# Altium schematic sheet schema.SchDoc(schema)
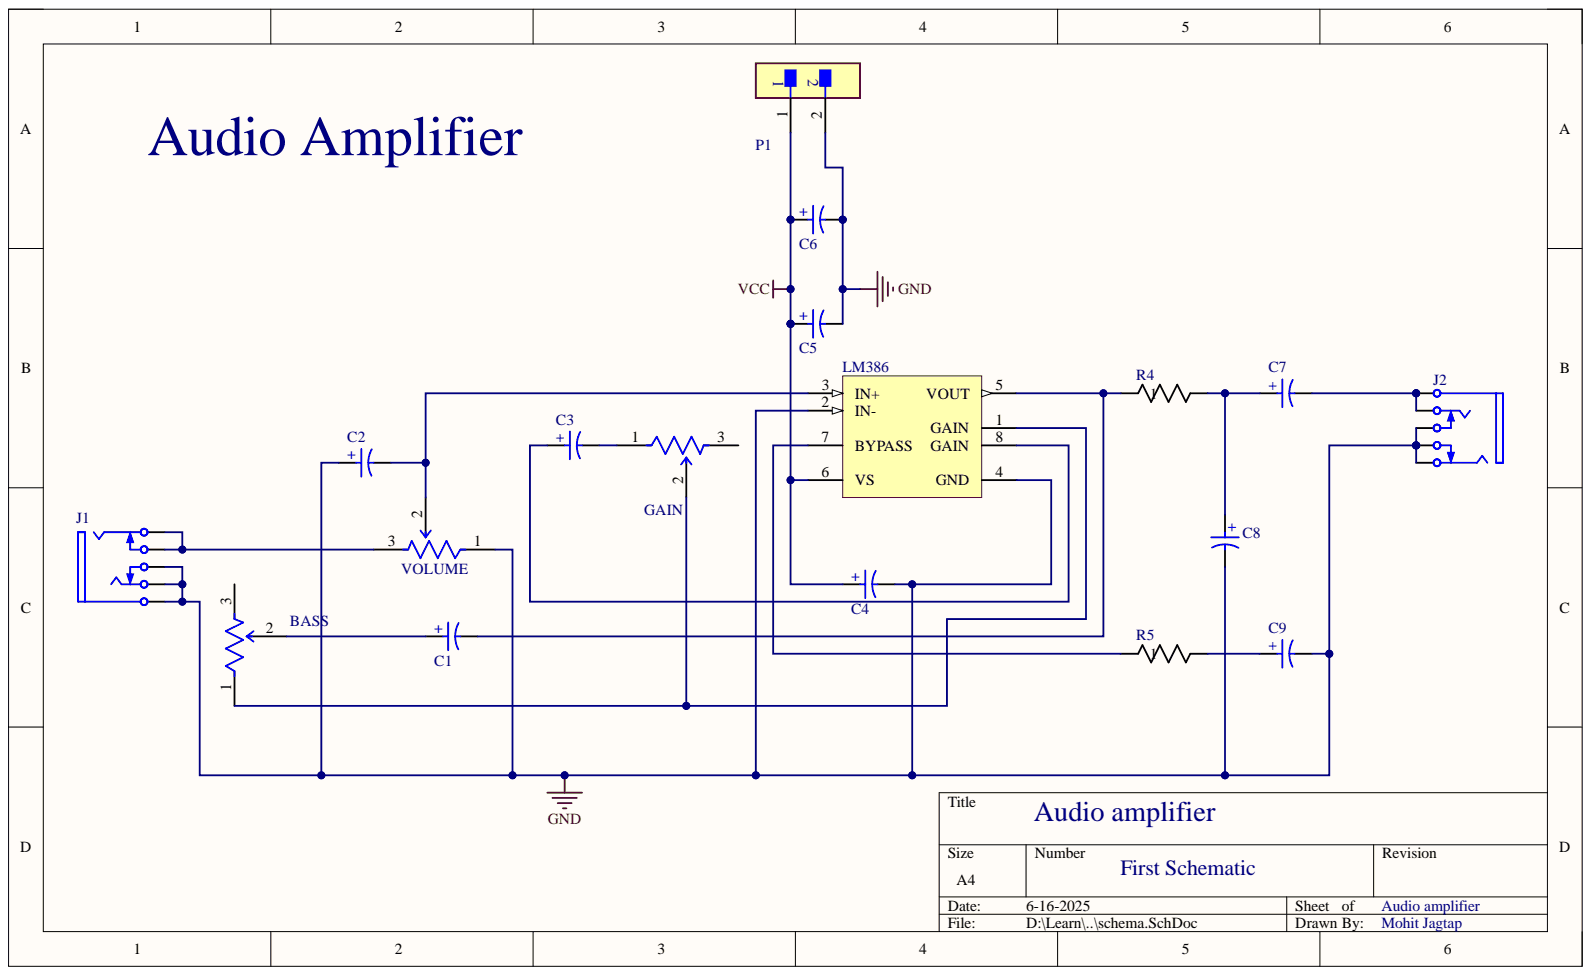
[diagram p0: schema.SchDoc]

## Parts
BASS  3352T-1-103LF  [FP-3352T-MFG]  (value not drawn)
C1  860020678025  [WCAP-ATG5_D13H25]  (value not drawn)
C2  860020678025  [WCAP-ATG5_D13H25]  (value not drawn)
C3  860020678025  [WCAP-ATG5_D13H25]  (value not drawn)
C4  860020678025  [WCAP-ATG5_D13H25]  (value not drawn)
C5  860020678025  [WCAP-ATG5_D13H25]  (value not drawn)
C6  860020678025  [WCAP-ATG5_D13H25]  (value not drawn)
C7  860020678025  [WCAP-ATG5_D13H25]  (value not drawn)
C8  860020678025  [WCAP-ATG5_D13H25]  (value not drawn)
C9  860020678025  [WCAP-ATG5_D13H25]  (value not drawn)
GAIN  3352T-1-103LF  [FP-3352T-MFG]  (value not drawn)
J1  35RASMT4BHNTRX  [SWIT-35RASMT4BHNTRX_V]  (value not drawn)
J2  35RASMT4BHNTRX  [SWIT-35RASMT4BHNTRX_V]  (value not drawn)
LM386  LM386M-1  [D0008A_M]  (value not drawn)
P1  M20-9990245  [FP-M20-9990245-MFG]  (value not drawn)
R4  AC01000006809JA100  [RES_AC01_VIS]  (value not drawn)
R5  AC01000006809JA100  [RES_AC01_VIS]  (value not drawn)
VOLUME  3352T-1-103LF  [FP-3352T-MFG]  (value not drawn)

## Nets
GND: C2.1 C4.2 C5.2 C6.2 C8.2 C9.2 J1.1 J1.3 J1.5 J2.2 J2.4 J2.5 LM386.2(IN-) LM386.4(GND) P1.2 VOLUME.1
VCC: C4.1 C5.1 C6.1 LM386.6(VS) P1.1
NetBASS_1: BASS.1 GAIN.2 LM386.1(GAIN)
NetBASS_2: BASS.2 C1.1
NetC1_2: C1.2 LM386.5(VOUT) R4.1
NetC2_2: C2.2 LM386.3(IN+) VOLUME.2
NetC3_1: C3.1 LM386.8(GAIN)
NetC3_2: C3.2 GAIN.1
NetC7_1: C7.1 C8.1 R4.2
NetC7_2: C7.2 J2.1 J2.3
NetC9_1: C9.1 R5.2
NetJ1_2: J1.2 J1.4 VOLUME.3
NetLM386_7: LM386.7(BYPASS) R5.1

Not connected: BASS 3; GAIN 3

## Library data of the parts
BASS GAIN VOLUME = CMP-07234-000038-1: THUMBWHEEL POT 10K OHM 0.5W TOP; Max Power Dissipation: 500mW; Number of Turns: 1; Resistance: 10kR; Resistance Tolerance: 20%; Temperature Coefficient: 100ppm/°C; Voltage Rating: 250V; Color: Black; Component Kind: Standard; Contact Plating: Tin; Depth: 9.53mm; Diameter: 8.89mm; Height: 0.15inch; Lead Free: Lead Free; Length: 8.89mm; Library Name: Altium Content Vault; Max Operating Temperature: 125°C; Military Standard: Not; Min Operating Temperature: -55°C; Mount: Through Hole; Package Quantity: 100; Packaging: Bag; Power Rating: 500mW; Radiation Hardening: No; REACH SVHC: No SVHC; Resistor Type: Cermet; RoHS Compliant: Yes; Series: Trimpot® 3352 - Open Frame; Taper: Linear; Technology: CERMET; Termination: Pin; Tolerance: 20%; Weight: 0.01oz; Width: 8.89mm
C1-C9 = CMP-03913-54202230-1: 860020678025 Alum. Electrolytic Cap 820µF 50V 13mm Radial WCAP-ATG5; Lifetime 2000h +105°C; Leakage Current: 410µA; Life (Hours): 2000hours; Material: Aluminium; Pitch: 5mm; Polarity: Polar; Ripple Current: 850mA; Tolerance: 20%; Capacitance: 820µF; Case/Package: Radial; Component Kind: Standard; Diameter: 13mm; Height: 25mm; Height - Seated (Max): 26.4922mm; Lead Pitch: 5.0038mm; Length: 25mm; Library Name: Capacitor_Ele_TH.IntLib; Max Operating Temperature: 105°C; Min Operating Temperature: -40°C; Mount: Through Hole; NexarPartId: 54202230; Packaging: Tape & Box (TB); REACH SVHC: Unknown; RoHS: Compliant; Voltage Rating: 50V; Voltage Rating (DC): 50V
J1 J2 = CMP-1772-00001-1: Female 3.5 mm Phone Jack, 5 Position, Height 5 mm, RoHS, Tape and Reel; Current Rating: 50 mA; Voltage Rating (DC): 12 V; Component Kind: Standard; ComponentLink1Description: Manufacturer URL; ComponentLink1URL: http://www.switchcraft.com/; ComponentLink2Description: Datasheet; ComponentLink2URL: http://www.switchcraft.com/Drawings/35rasmt4bhntrx_cd.pdf; Contact Material: Silver; Contact Plating: Silver; DatasheetVersion: Rev. C, 07/2014; Library Name: Altium Content Vault; Mount: Through Hole; Package Quantity: 3000; PackageDescription: Female 3.5 mm Phone Jack, 5 Position, Height 5 mm; PackageReference: 35RASMT4BHNTRX; Packaging: Tape and Reel; RoHSCompliant: true
LM386 = CMP-0057-00421-4: Low Voltage Audio Power Amplifier, 8-pin Narrow SOIC; Manufacturer: Texas Instruments; CompType: Audio IC; PackageTopMarking: NSZXTT*LM386*M-1; PowerWise: N; Code_IPC: SOIC127P600-8; Code_JEDEC: MS-012-AA; Component Kind: Standard; ComponentLink1Description: Datasheet URL; ComponentLink1URL: http://www.ti.com/general/docs/lit/getliterature.tsp?genericPartNumber=LM386&fileType=pdf; Library Name: Altium Content Vault; PackageDescription: SOIC Narrow, 4.9 x 3.9 x 1.45mm, 8 Lead, 1.27mm Pitch; PackageReference: D0008A; PinCount: 8; RoHS: N
P1 = CMP-15831-000105-1: CONN HEADER VERT 2POS 2.54MM; Connector Type: Male Pin; Contact Resistance: 25mR; Current: 3A; Gender: Male; Insulation Resistance: 10GR; Mated Stacking Heights: 16.54mm; Mating Cycles: 300; Number of Contacts: 2; Voltage: 50V; Voltage Rating (AC): 750V; Case/Package: SIL; Color: Black; Component Kind: Standard; Contact Finish - Mating: GOLD; Contact Material: Brass; Contact Mating Length: 0.24inch; Contact Plating: Gold; Contact Shape: Square; Contact Type: Male Pin; Current Rating: 3A; Depth: 2.54mm; Fastening Type: Pull; Flammability Rating: UL94 V-0; Height: 0.12inch; Housing Color: Black; Housing Material: Nylon; Insulation Color: Black; Insulation Material: Nylon; Lead Pitch: 2.54mm; Length: 5.08mm; Max Current Rating: 3A; Max Operating Temperature: 105°C; Min Operating Temperature: -40°C; Mount: Through Hole; Number of Poles: 2; Number of Positions: 2; Number of Rows: 1; Orientation: Straight; Package Quantity: 1000; Packaging: Bulk; Pitch: 2.54mm; Radiation Hardening: No; Reach SVHC Compliant: Yes; Series: M20; Termination: Solder; Weight: 0.25lb; Width: 0.25inch; Working Voltage: 750V; Library Name: berg-2.IntLib
R4 R5 = CMP-00030-39747107-1: AC01000006809JA100 Resistor, 68 Ohm, ± 5%, 1 W, Axial Leaded, Wirewound, General Purpose; Lead Diameter: 800µm; Max Power Dissipation: 1W; Resistance: 68Ω; Temperature Coefficient: 180ppm/°C; Voltage Rating (DC): 8V; Case/Package: Axial; Component Kind: Standard; Composition: Wirewound; Contact Plating: Tin; Diameter: 4.3mm; Features: Flame Retardant Coating; Length: 11mm; Library Name: resistor-TH.IntLib; Max Operating Temperature: 275°C; Min Operating Temperature: -40°C; NexarPartId: 39747107; Number of Pins: 2; Number of Terminations: 2; Packaging: Tape & Box (TB); Power Rating: 1W; REACH SVHC: Unknown; RoHS: Compliant; Schedule B: 8533210080, 8533210080|8533210080|8533210080|8533210080|8533210080; Termination: Axial; Tolerance: 5%
